# Altium schematic sheet Optic_PWM.SchDoc
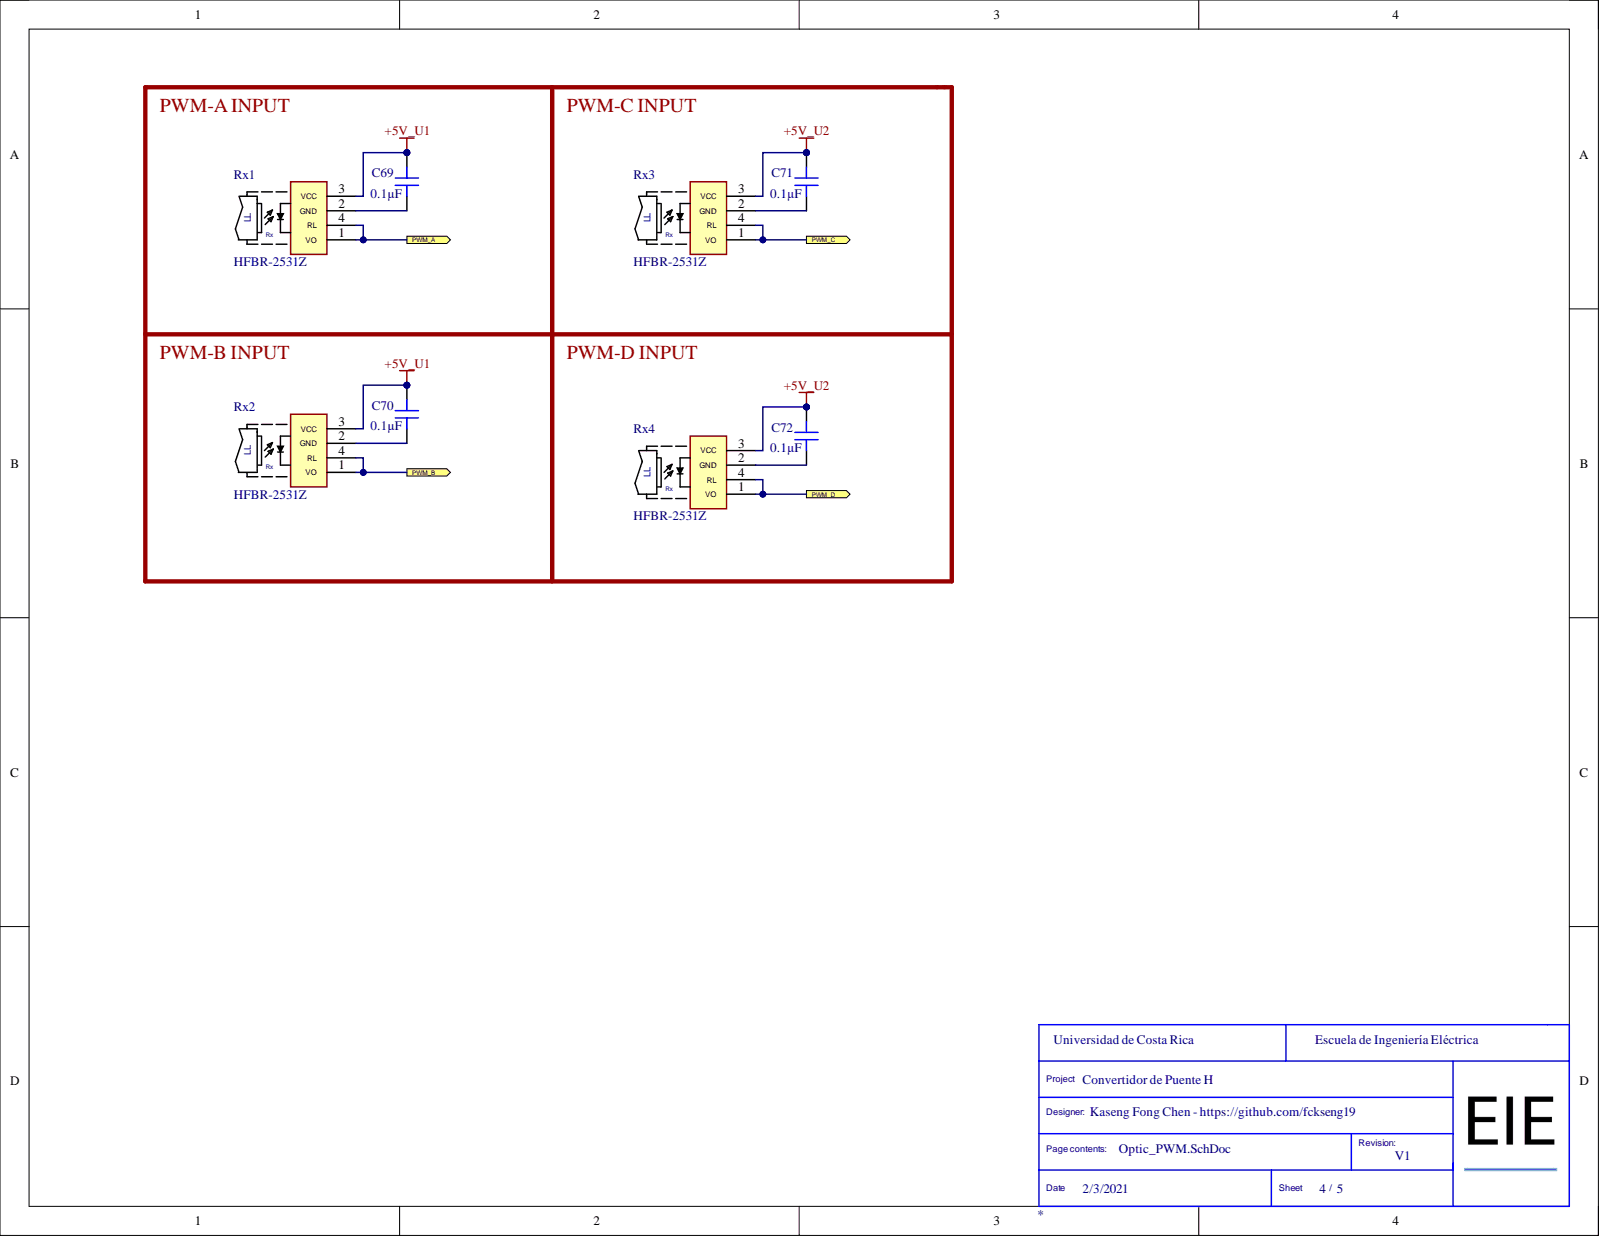
[diagram p0: Optic_PWM.SchDoc]

## Parts
C69  0.1µF  [CAP 0603_1608]
C70  0.1µF  [CAP 0603_1608]
C71  0.1µF  [CAP 0603_1608]
C72  0.1µF  [CAP 0603_1608]
Rx1  HFBR-2531Z  [HFBR-15X1V]
Rx2  HFBR-2531Z  [HFBR-15X1V]
Rx3  HFBR-2531Z  [HFBR-15X1V]
Rx4  HFBR-2531Z  [HFBR-15X1V]

## Ports
PWM_A, PWM_B, PWM_C, PWM_D

## Nets
+5V_U1: C69.2 C70.2 Rx1.3(VCC) Rx2.3(VCC)
+5V_U2: C71.2 C72.2 Rx3.3(VCC) Rx4.3(VCC)
PWM_A: Rx1.1(VO) Rx1.4(RL) ; port PWM_A
PWM_B: Rx2.1(VO) Rx2.4(RL) ; port PWM_B
PWM_C: Rx3.1(VO) Rx3.4(RL) ; port PWM_C
PWM_D: Rx4.1(VO) Rx4.4(RL) ; port PWM_D
NetC69_1: C69.1 Rx1.2(GND)
NetC70_1: C70.1 Rx2.2(GND)
NetC71_1: C71.1 Rx3.2(GND)
NetC72_1: C72.1 Rx4.2(GND)

## Library data of the parts
C69-C72 = C0603C104M5RACTU: CAP CER 0.1UF 50V X7R 0603; Manufacturer: KEMET; Database Table Name: Capacitors - Ceramic - 0603; Tolerance: ±20%; Applications: Bypass, Decoupling; Capacitance: 0.1µF; Component Kind: Standard; ComponentLink1Description: Datasheet; ComponentLink1URL: https://api.kemet.com/component-edge/download/datasheet/C0603C104M5RACTU.pdf; ComponentLink2Description: DigiKey Link; ComponentLink2URL: http://digikey.com/product-detail/en/kemet/C0603C104M5RACTU/399-7845-1-ND/3471568; Device Package: 0603 (1608 Metric); LastUpdated: 2/9/2020 01:03:01; Library Name: Celestial Altium Library - altium_library.DbLib; Lifecycle Status: Active; Minimum Order: 1; Mounting Type: Surface Mount, MLCC; Operating Temperature: -55°C ~ 125°C; Package / Case: 0603 (1608 Metric); Packaging: TapeAndReel; Part Number: C0603C104M5RACTU; Part Status: Active; PartId: 17854; Price: 0.01; Series: SMD Comm X7R; Size / Dimension: 0.063" L x 0.031" W (1.60mm x 0.80mm); Supplier 1: DigiKey; Supplier Part Number 1: 399-7845-1-ND; Temperature Coefficient: X7R; Thickness (Max): 0.034" (0.87mm); Value: 0.1µF; Voltage - Rated: 50V
Rx1-Rx4 = HFBR-2531Z: RX Optical Fiber 5MBd 6-Pin; Manufacturer: Broadcom Avago; Data Rate: 115Kbps; Fall Time: 40ns; Max Output Current: 25mA; Max Power Dissipation: 40mW; Max Supply Voltage: 7V; Min Supply Voltage: -500mV; Operating Supply Current: 10mA; Operating Supply Voltage: 5V; Rise Time: 80ns; Wavelength: 660nm; Category: Fiber Optics; Component Kind: Standard; Height: 18.8mm; Lead Free: Lead Free; Length: 18.3mm; Manufacturer Part Number: HFBR-2531Z; Max Operating Temperature: 85°C; Min Operating Temperature: -40°C; Mount: Through Hole; Number of Pins: 6; Output Current: 25mA; Package Quantity: 200; Packaging: Rail/Tube; Pricing 1: 1=12,98000, 10=9,79400, 100=8,22730, 500=7,55568, 1000=7,39200 (USD); Radiation Hardening: No; REACH SVHC: No SVHC; RoHS: Yes; RoHS Compliant: Yes; Series: HFBR-2; Stock 1: 6696; Supplier 1: Digi-Key; Supplier Part Number 1: 516-2067-ND; Weight: 0.021164oz; Width: 12.2mm; Library Name: KasengLIb.SchLib
